# Altium schematic sheet CAN.SchDoc
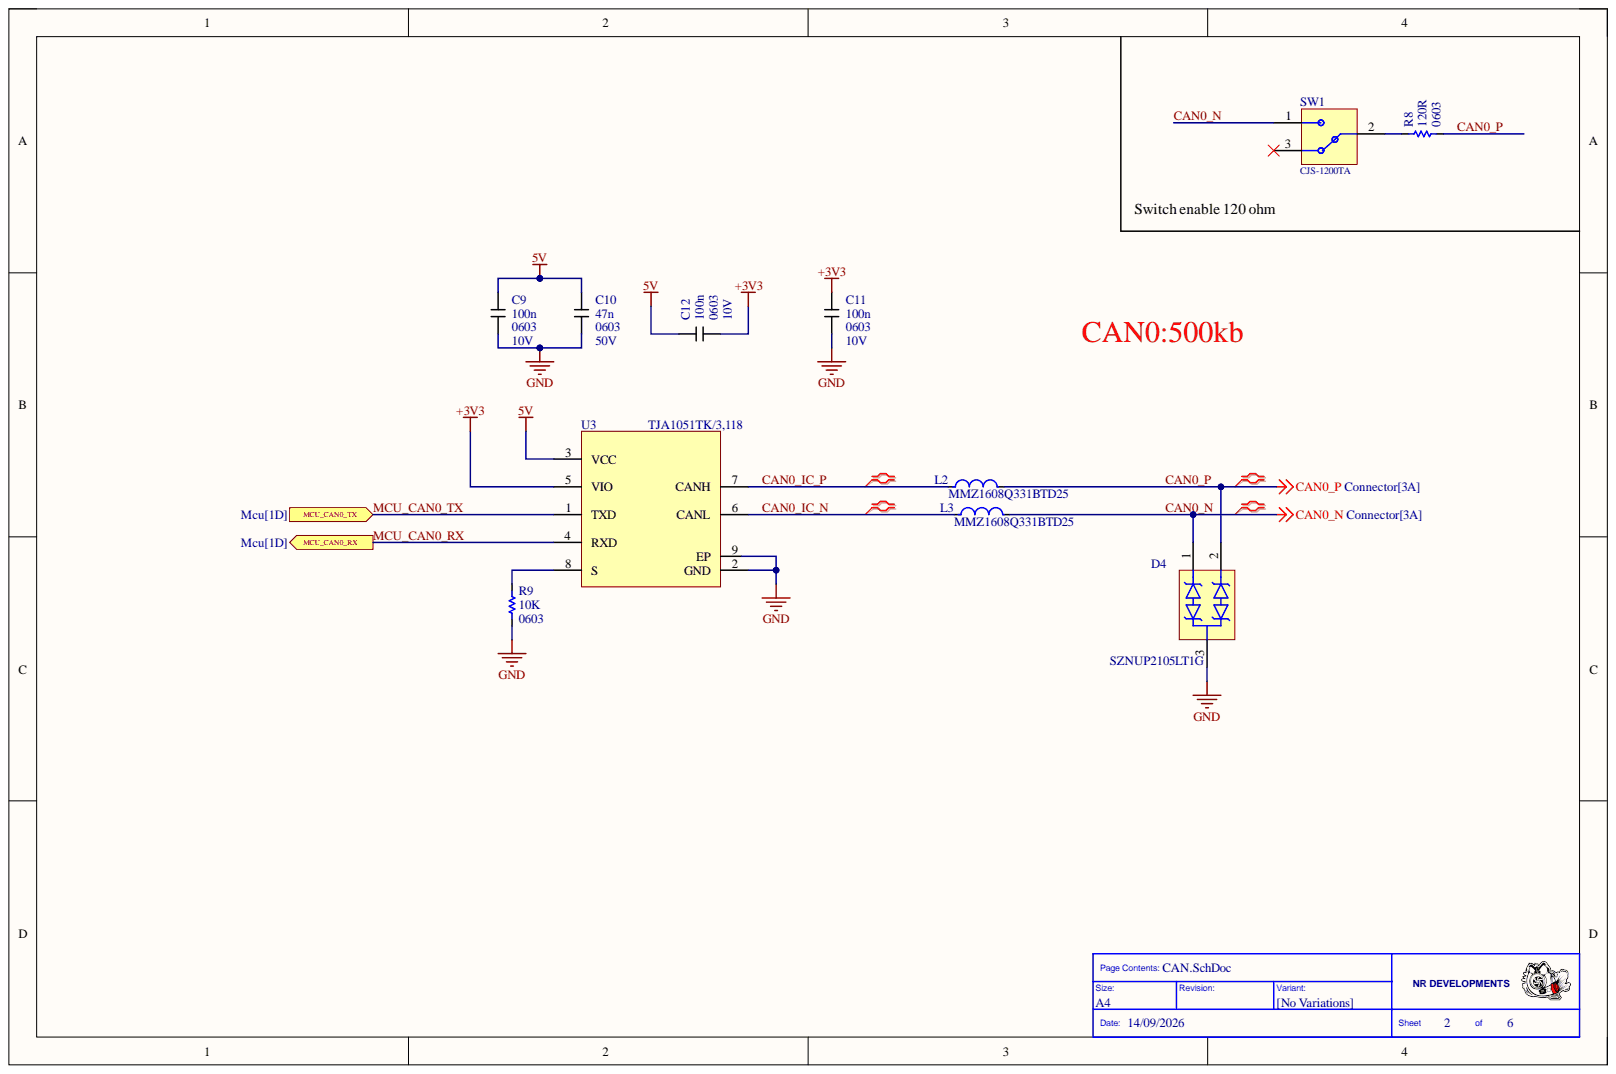
[diagram p0: CAN.SchDoc]

## Parts
C9  value 100n  Case: 0603  Voltage: 10V  [CAPC1608X09M]  (hidden comment: C0603C104K5RACTU)
C10  value 47n  Case: 0603  Voltage: 50V  [CAPC1608X09M]  (hidden comment: C0603X473K5RAC3316)
C11  value 100n  Case: 0603  Voltage: 10V  [CAPC1608X09M]  (hidden comment: C0603C104K5RACTU)
C12  value 100n  Case: 0603  Voltage: 10V  [CAPC1608X09M]  (hidden comment: C0603C104K5RACTU)
D4  value SZNUP2105LT1G  PartNumber: SZNUP2105LT1G  [SOT95P240X120-3N]  (hidden comment: SM712-02HTG)
L2  value MMZ1608Q331BTD25  PartNumber: MMZ1608Q331BTD25  [INDC1608X09N]  (hidden comment: BLM18HB331SN1D)
L3  value MMZ1608Q331BTD25  PartNumber: MMZ1608Q331BTD25  [INDC1608X09N]  (hidden comment: BLM18HB331SN1D)
R8  value 120R  Case: 0603  [RESC1608X06M]  (hidden comment: CRCW0603120RFKEA)
R9  value 10K  Case: 0603  [RESC1608X06M]  (hidden comment: CRCW060310K0FKEA)
SW1  value CJS-1200TA  PartNumber: CJS-1200TA  [SW_CJS-1200TA]  (hidden comment: Switch SPDT)
U3  TJA1051TK/3,118  [SON65P300X300X100-9N-D]

## Ports
MCU_CAN0_RX, MCU_CAN0_TX

## Nets
5V: C9.1 C10.1 C12.1 U3.3(VCC)
+3V3: C11.1 C12.2 U3.5(VIO)
GND: C9.2 C10.2 C11.2 D4.3 R9.1 U3.2(GND) U3.9(EP)
CAN0_IC_N: L3.1 U3.6(CANL)
CAN0_IC_P: L2.1 U3.7(CANH)
CAN0_N: D4.1 L3.2 SW1.1
CAN0_P: D4.2 L2.2 R8.1
MCU_CAN0_RX: U3.4(RXD) ; port MCU_CAN0_RX
MCU_CAN0_TX: U3.1(TXD) ; port MCU_CAN0_TX
NetR8_2: R8.2 SW1.2
NetR9_2: R9.2 U3.8(S)

Not connected: SW1 3

## Library data of the parts
C9-C12 = CAP: Manufacturer: KEMET; SMD/PTH: SMD; Component Kind: Standard; Library Name: My_LIB.SchLib
  C9 C11 C12: Description: C0603C104K5RACTU; PartNumber: C0603C104K5RACTU
  C10: Description: C0603X473K5RAC3316; PartNumber: C0603X473K5RAC3316
D4 = SM712-02HTG: ESD Diode bid; Manufacturer: Onsemi; SMD/PTH: SMD; Case: SOT23-3; Component Kind: Standard; Library Name: My_LIB.SchLib
L2 L3 = IND: Manufacturer: TDK; SMD/PTH: SMD; Case: 0603; Component Kind: Standard; Description: BLM18HB331SN1D; Library Name: My_LIB.SchLib
R8 R9 = Resistor: Manufacturer: Vishay; SMD/PTH: SMD; Component Kind: Standard; Library Name: My_LIB.SchLib
  R8: Description: CRCW0603120RFKEA; PartNumber: CRCW0603120RFKEA
  R9: Description: CRCW060310K0FKEA; PartNumber: CRCW060310K0FKEA
SW1 = SWITCH_SPDT: Manufacturer: Nidec Components; Component Kind: Standard; Library Name: My_LIB.SchLib
U3 = TJA1051TK/3: CAN HIGH-SPEED CAN TRANSCEIVER 3.3V / 5V Pin VIO; Manufacturer: NXP Semiconductors; SMD/PTH: SMD; Case: HVSON-8; Component Kind: Standard; Library Name: My_LIB.SchLib; PartNumber: TJA1051TK/3,118; Value: TJA1051TK/3,118
